# Altium schematic sheet Sheet1.SchDoc
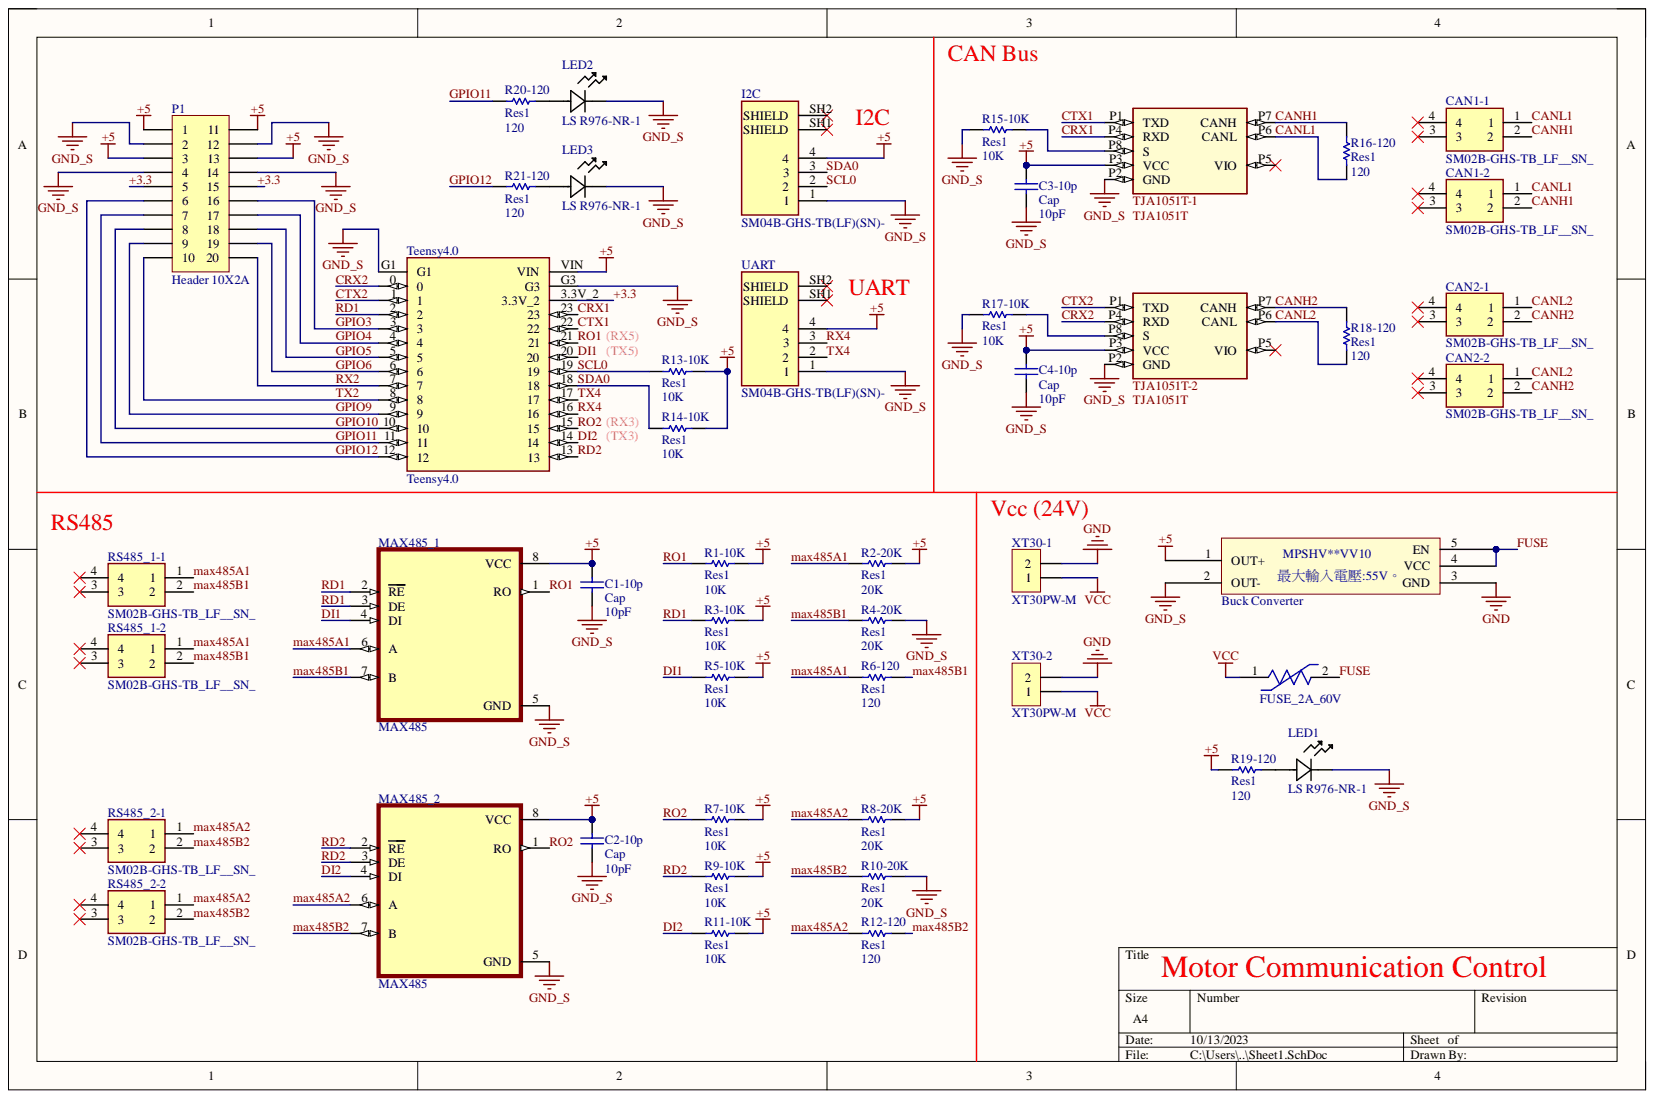
[diagram p0: Sheet1.SchDoc]

## Parts
Buck_Converter  MPSHV**VV10  [PCBComponent_1]
C1-10p  Cap  value 10pF  [6-0805_N]
C2-10p  Cap  value 10pF  [6-0805_N]
C3-10p  Cap  value 10pF  [6-0805_N]
C4-10p  Cap  value 10pF  [6-0805_N]
CAN1-1  SM02B-GHS-TB_LF__SN_  [SM02BGHSTBLFSN]
CAN1-2  SM02B-GHS-TB_LF__SN_  [SM02BGHSTBLFSN]
CAN2-1  SM02B-GHS-TB_LF__SN_  [SM02BGHSTBLFSN]
CAN2-2  SM02B-GHS-TB_LF__SN_  [SM02BGHSTBLFSN]
FUSE_2A_60V  0ZCF0100AF2A  [Bel_Fuse_0_MFG]  (value not drawn)
I2C  SM04B-GHS-TB(LF)(SN)-  [JST_SM04B-GHS-TB(LF)(SN)-]
LED1  LS R976-NR-1  [LED_LS R976_AOS]
LED2  LS R976-NR-1  [LED_LS R976_AOS]
LED3  LS R976-NR-1  [LED_LS R976_AOS]
MAX485_1  MAX485  [MAX485]
MAX485_2  MAX485  [MAX485]
P1  Header 10X2A  [HDR2X10_CEN]
R1-10K  Res1  [6-0805_N]
R2-20K  Res1  value 20K  [6-0805_N]
R3-10K  Res1  value 10K  [6-0805_N]
R4-20K  Res1  value 20K  [6-0805_N]
R5-10K  Res1  value 10K  [6-0805_N]
R6-120  Res1  value 120  [6-0805_N]
R7-10K  Res1  value 10K  [6-0805_N]
R8-20K  Res1  value 20K  [6-0805_N]
R9-10K  Res1  value 10K  [6-0805_N]
R10-20K  Res1  value 20K  [6-0805_N]
R11-10K  Res1  value 10K  [6-0805_N]
R12-120  Res1  value 120  [6-0805_N]
R13-10K  Res1  value 10K  [6-0805_N]
R14-10K  Res1  value 10K  [6-0805_N]
R15-10K  Res1  value 10K  [6-0805_N]
R16-120  Res1  value 120  [6-0805_N]
R17-10K  Res1  value 10K  [6-0805_N]
R18-120  Res1  value 120  [6-0805_N]
R19-120  Res1  value 120  [6-0805_N]
R20-120  Res1  value 120  [6-0805_N]
R21-120  Res1  value 120  [6-0805_N]
RS485_1-1  SM02B-GHS-TB_LF__SN_  [SM02BGHSTBLFSN]
RS485_1-2  SM02B-GHS-TB_LF__SN_  [SM02BGHSTBLFSN]
RS485_2-1  SM02B-GHS-TB_LF__SN_  [SM02BGHSTBLFSN]
RS485_2-2  SM02B-GHS-TB_LF__SN_  [SM02BGHSTBLFSN]
Teensy4.0  Teensy4.0  [Teensy4.0]
TJA1051T-1  TJA1051T  [*SOIC-8_TJA1051T(Primary)]
TJA1051T-2  TJA1051T  [*SOIC-8_TJA1051T(Primary)]
UART  SM04B-GHS-TB(LF)(SN)-  [JST_SM04B-GHS-TB(LF)(SN)-]
XT30-1  XT30PW-M  [XT30PW-M]
XT30-2  XT30PW-M  [XT30PW-M]

## Nets
+5: Buck_Converter.1(OUT+) C1-10p.2 C2-10p.2 C3-10p.2 C4-10p.2 I2C.4 MAX485_1.8(VCC) MAX485_2.8(VCC) P1.1 P1.3 P1.11 P1.13 R1-10K.2 R2-20K.2 R3-10K.2 R5-10K.2 R7-10K.2 R8-20K.2 R9-10K.2 R11-10K.2 R13-10K.2 R14-10K.2 R19-120.1 Teensy4.0.VIN TJA1051T-1.P3(VCC) TJA1051T-2.P3(VCC) UART.4
GND: Buck_Converter.3(GND) XT30-1.2 XT30-2.2
GND_S: Buck_Converter.2(OUT-) C1-10p.1 C2-10p.1 C3-10p.1 C4-10p.1 I2C.1 LED1.1 LED2.1 LED3.1 MAX485_1.5(GND) MAX485_2.5(GND) P1.2 P1.4 P1.12 P1.14 R4-20K.2 R10-20K.2 R15-10K.1 R17-10K.1 Teensy4.0.G1 Teensy4.0.G3 TJA1051T-1.P2(GND) TJA1051T-2.P2(GND) UART.1
VCC: FUSE_2A_60V.1 XT30-1.1 XT30-2.1
+3.3: P1.5 P1.15 Teensy4.0.3.3V_2
CANH1: CAN1-1.2 CAN1-2.2 R16-120.2 TJA1051T-1.P7(CANH)
CANH2: CAN2-1.2 CAN2-2.2 R18-120.2 TJA1051T-2.P7(CANH)
CANL1: CAN1-1.1 CAN1-2.1 R16-120.1 TJA1051T-1.P6(CANL)
CANL2: CAN2-1.1 CAN2-2.1 R18-120.1 TJA1051T-2.P6(CANL)
CRX1: Teensy4.0.23 TJA1051T-1.P4(RXD)
CRX2: Teensy4.0.0 TJA1051T-2.P4(RXD)
CTX1: Teensy4.0.22 TJA1051T-1.P1(TXD)
CTX2: Teensy4.0.1 TJA1051T-2.P1(TXD)
DI1: MAX485_1.4(DI) R5-10K.1 Teensy4.0.20
DI2: MAX485_2.4(DI) R11-10K.1 Teensy4.0.14
FUSE: Buck_Converter.4(VCC) Buck_Converter.5(EN) FUSE_2A_60V.2
GPIO3: P1.16 Teensy4.0.3
GPIO4: P1.17 Teensy4.0.4
GPIO5: P1.18 Teensy4.0.5
GPIO6: P1.19 Teensy4.0.6
GPIO9: P1.9 Teensy4.0.9
GPIO10: P1.8 Teensy4.0.10
GPIO11: P1.7 R20-120.1 Teensy4.0.11
GPIO12: P1.6 R21-120.1 Teensy4.0.12
max485A1: MAX485_1.6(A) R2-20K.1 R6-120.1 RS485_1-1.1 RS485_1-2.1
max485A2: MAX485_2.6(A) R8-20K.1 R12-120.1 RS485_2-1.1 RS485_2-2.1
max485B1: MAX485_1.7(B) R4-20K.1 R6-120.2 RS485_1-1.2 RS485_1-2.2
max485B2: MAX485_2.7(B) R10-20K.1 R12-120.2 RS485_2-1.2 RS485_2-2.2
RD1: MAX485_1.2(RE) MAX485_1.3(DE) R3-10K.1 Teensy4.0.2
RD2: MAX485_2.2(RE) MAX485_2.3(DE) R9-10K.1 Teensy4.0.13
RO1: MAX485_1.1(RO) R1-10K.1 Teensy4.0.21
RO2: MAX485_2.1(RO) R7-10K.1 Teensy4.0.15
RX2: P1.20 Teensy4.0.7
RX4: Teensy4.0.16 UART.3
SCL0: I2C.2 R13-10K.1 Teensy4.0.19
SDA0: I2C.3 R14-10K.1 Teensy4.0.18
TX2: P1.10 Teensy4.0.8
TX4: Teensy4.0.17 UART.2
NetLED1_2: LED1.2 R19-120.2
NetLED2_2: LED2.2 R20-120.2
NetLED3_2: LED3.2 R21-120.2
NetR15-10K_2: R15-10K.2 TJA1051T-1.P8(S)
NetR17-10K_2: R17-10K.2 TJA1051T-2.P8(S)

Not connected: CAN1-1 3 4; CAN1-2 3 4; CAN2-1 3 4; CAN2-2 3 4; I2C SH1(SHIELD) SH2(SHIELD); RS485_1-1 3 4; RS485_1-2 3 4; RS485_2-1 3 4; RS485_2-2 3 4; TJA1051T-1 P5(VIO); TJA1051T-2 P5(VIO); UART SH1(SHIELD) SH2(SHIELD)

## Library data of the parts
Buck_Converter = MPSHV**VV10: 商品名称：MPSHVCVV10 DCDC降压电源模块    商品型号：MPSxxxV1x    输入电压：最高达55V（高压版）  28V（低压版）    输出电流：直流2A（高压版）直流3A（低压版）    尺寸：36长*18宽    关断功能：EN引脚接地或悬空，模块不工作，输出电压为0V    EN引脚接VIN+，模块工作，输出电压*V; Component Kind: Standard; Library Name: Voltage_Converter_to_5V.IntLib
C1-10p C2-10p C3-10p C4-10p = Cap: Capacitor; Component Kind: Standard; LatestRevisionDate: 17-Jul-2002; LatestRevisionNote: Re-released for DXP Platform.; Library Name: Miscellaneous Devices.IntLib; PackageDescription: Radial Cap, Thru-Hole; 2 Leads; 0.3 in Pin Spacing; PackageReference: RAD-0.3; Published: 8-Jun-2000; Signal Integrity: Cap; Simulation: CAP; Publisher: Altium Limited
CAN1-1 CAN1-2 CAN2-1 CAN2-2 RS485_1-1 RS485_1-2 RS485_2-1 RS485_2-2 = SM02B-GHS-TB_LF__SN_: Connector; Manufacturer_Name: JST (JAPAN SOLDERLESS TERMINALS); Manufacturer_Part_Number: SM02B-GHS-TB(LF)(SN); Arrow Part Number: SM02B-GHS-TB(LF)(SN); Arrow Price/Stock: https://www.arrow.com/en/products/sm02b-ghs-tb-lf-sn/jst-manufacturing; Component Kind: Standard; Datasheet Link: https://datasheet.datasheetarchive.com/originals/distributors/Datasheets_SAMA/e4ef010dc292ea31091454f6c0afb63a.pdf; Height: 4.25mm; Library Name: JST GH.SchLib
FUSE_2A_60V = 0ZCF0100AF2A: Polymeric PTC Resettable Fuse 60V 1A Ih Surface Mount 2920 (7351 Metric), Concave; Manufacturer: Bel Fuse; Automotive Grade: Grade 3; Current Rating: 100A; Database Table Name: Components$; Resistance: 0.09-0.41Ω; Symbol Hash: 1c6d77a0208143737455a0c7eb396be881ce793a7a6509f329007dd2ec82efd7; Trip Current: 2A; Trip Time: 0.5s; Value: 0.09-0.41Ω; Verification Version: 0.0.0.3; Automotive: Yes; Component Kind: Standard; ComponentLink1Description: Upverter; ComponentLink1URL: https://upverter.com/part/id/1a8b8c25a09ddeb3/; ComponentLink2Description: Datasheet; ComponentLink2URL: https://belfuse.com/resources/datasheets/circuitprotection/ds-cp-0zcf-series.pdf; Datasheet Path: datasheets/Fuse_Bel_Fuse_0ZCF0100AF2A_eec.pdf; Digikey Description: PTC RESET FUSE 60V 1A 2920; Digikey Part Number: 507-2070-1-ND; Height: 1.7mm; helpURL: https://upverter.com/part/id/1a8b8c25a09ddeb3/; Hold Current: 1A; Lead Free: Yes; Library Name: Fuse2A60V.DbLib; Mouser Part Number: 530-0ZCF0100AF2A; Package: 0; Part Number: 0ZCF0100AF2A; RoHS: TRUE; Supplier 1: Digi-Key; Supplier 2: Mouser; Supplier Part Number 1: 507-2070-1-ND; Supplier Part Number 2: 530-0ZCF0100AF2A; Temperature: -40°C to +85°C; Temperature Range High: +85°C; Temperature Range Low: -40°C; Voltage Rating: 60V
I2C UART = SM04B-GHS-TB(LF)(SN)-: Connector Header Surface Mount, Right Angle 4 position 0.049 _1.25mm_; MF: JST Sales; MP: SM04B-GHS-TB_LF__SN_-; Availability: Unavailable; Component Kind: Standard; Library Name: SM04B-GHS-TB_LF__SN_.IntLib; Package: None; Price: None; SnapEDA_Link: https://www.snapeda.com/parts/SM04B-GHS-TB%28LF%29%28SN%29-/JST%20Sales%20America%20Inc./view-part/2759740/?ref=snap
LED1-LED3 = LS R976-NR-1: No Description Available; Mfr_Name: ams OSRAM; Component Kind: Standard; Library Name: SMD0805LED.IntLib
P1 = Header 10X2A: Header, 10-Pin, Dual row; Component Kind: Standard; LatestRevisionDate: 17-Jul-2002; LatestRevisionNote: Re-released for DXP Platform.; Library Name: Miscellaneous Connectors.SchLib; Signal Integrity: Connector; Publisher: Altium Limited
R1-10K R7-10K R13-10K R15-10K R17-10K = Res1: Resistor; Value: 10K; Component Kind: Standard; LatestRevisionDate: 17-Jul-2002; LatestRevisionNote: Re-released for DXP Platform.; Library Name: Miscellaneous Devices.IntLib; PackageDescription: Axial Device, Thru-Hole; 2 Leads; 0.3 in Pin Spacing; PackageReference: AXIAL-0.3; Published: 8-Jun-2000; Signal Integrity: Res; Simulation: RESISTOR; Publisher: Altium Limited
R2-20K R8-20K = Res1: Resistor; Value: 20K; Component Kind: Standard; LatestRevisionDate: 17-Jul-2002; LatestRevisionNote: Re-released for DXP Platform.; Library Name: Miscellaneous Devices.IntLib; PackageDescription: Axial Device, Thru-Hole; 2 Leads; 0.3 in Pin Spacing; PackageReference: AXIAL-0.3; Published: 8-Jun-2000; Signal Integrity: Res; Simulation: RESISTOR; Publisher: Altium Limited
R3-10K R4-20K R5-10K R6-120 R9-10K R10-20K R11-10K R12-120 R14-10K R16-120 R18-120 R19-120 R20-120 R21-120 = Res1: Resistor; Component Kind: Standard; LatestRevisionDate: 17-Jul-2002; LatestRevisionNote: Re-released for DXP Platform.; Library Name: Miscellaneous Devices.IntLib; PackageDescription: Axial Device, Thru-Hole; 2 Leads; 0.3 in Pin Spacing; PackageReference: AXIAL-0.3; Published: 8-Jun-2000; Signal Integrity: Res; Simulation: RESISTOR; Publisher: Altium Limited
TJA1051T-1 TJA1051T-2 = TJA1051T: 1/1 Transceiver Half CANbus 8-SO; MF: NXP USA; Check_prices: https://www.snapeda.com/parts/TJA1051T/NXP+USA+Inc./view-part/?ref=eda; Component Kind: Standard; Library Name: TJA1051T.SchLib; MP: TJA1051T; Package: VDFN-8 NXP Semiconductors; SnapEDA_Link: https://www.snapeda.com/parts/TJA1051T/NXP+USA+Inc./view-part/?ref=snap
MAX485_1 MAX485_2 = MAX485: Component Kind: Standard; Library Name: IVAM_2020.SchLib
Teensy4.0 = Teensy4.0: Component Kind: Standard; Library Name: Mylib.SchLib
XT30-1 XT30-2 = XT30PW-M: Component Kind: Standard; Library Name: YCLIB.SchLib
